# Altium schematic sheet Connectors.SchDoc
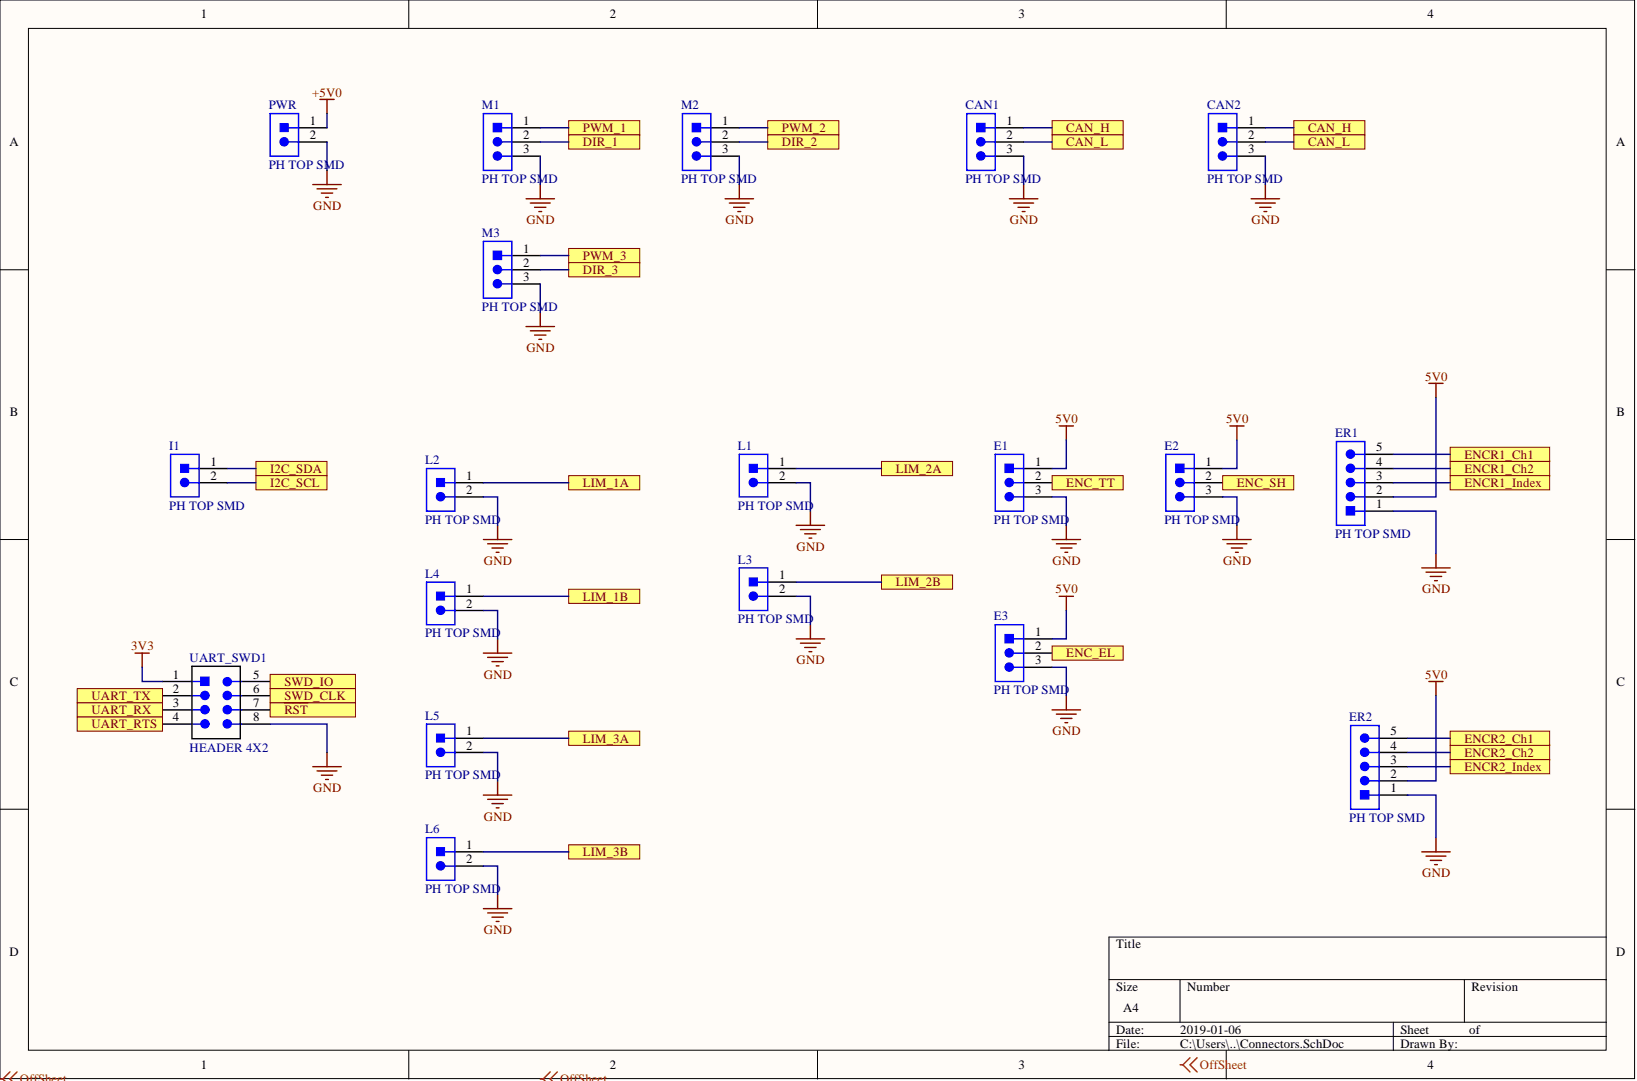
[diagram p0: Connectors.SchDoc]

## Parts
CAN1  PH TOP SMD  [JST-B3B-SM4-TB]
CAN2  PH TOP SMD  [JST-B3B-SM4-TB]
E1  PH TOP SMD  [JST-B3B-SM4-TB]
E2  PH TOP SMD  [JST-B3B-SM4-TB]
E3  PH TOP SMD  [JST-B3B-SM4-TB]
ER1  PH TOP SMD  [JST-B5B-SM4-TB]
ER2  PH TOP SMD  [JST-B5B-SM4-TB]
I1  PH TOP SMD  [JST-B2B-SM4-TB]
L1  PH TOP SMD  [JST-B2B-SM4-TB]
L2  PH TOP SMD  [JST-B2B-SM4-TB]
L3  PH TOP SMD  [JST-B2B-SM4-TB]
L4  PH TOP SMD  [JST-B2B-SM4-TB]
L5  PH TOP SMD  [JST-B2B-SM4-TB]
L6  PH TOP SMD  [JST-B2B-SM4-TB]
M1  PH TOP SMD  [JST-B3B-SM4-TB]
M2  PH TOP SMD  [JST-B3B-SM4-TB]
M3  PH TOP SMD  [JST-B3B-SM4-TB]
PWR  PH TOP SMD  [JST-B2B-SM4-TB]
UART_SWD1  HEADER 4X2  [HEADER_2X4]

## Ports
CAN_H, CAN_L, DIR_1, DIR_2, DIR_3, ENC_EL, ENC_SH, ENC_TT, ENCR1_Ch1, ENCR1_Ch2, ENCR1_Index, ENCR2_Ch1, ENCR2_Ch2, ENCR2_Index, I2C_SCL, I2C_SDA, LIM_1A, LIM_1B, LIM_2A, LIM_2B, LIM_3A, LIM_3B, PWM_1, PWM_2, PWM_3, SWD_CLK, SWD_IO, UART_RTS, UART_RX, UART_TX, ~{RST}

## Nets
3V3: UART_SWD1.1
5V0: E1.1 E2.1 E3.1 ER1.2 ER2.2
+5V0: PWR.1
GND: CAN1.3 CAN2.3 E1.3 E2.3 E3.3 ER1.1 ER2.1 L1.2 L2.2 L3.2 L4.2 L5.2 L6.2 M1.3 M2.3 M3.3 PWR.2 UART_SWD1.8
~{RST}: UART_SWD1.7 ; port ~{RST}
CAN_H: CAN1.1 CAN2.1 ; port CAN_H
CAN_L: CAN1.2 CAN2.2 ; port CAN_L
DIR_1: M1.2 ; port DIR_1
DIR_2: M2.2 ; port DIR_2
DIR_3: M3.2 ; port DIR_3
ENC_EL: E3.2 ; port ENC_EL
ENC_SH: E2.2 ; port ENC_SH
ENC_TT: E1.2 ; port ENC_TT
ENCR1_Ch1: ER1.5 ; port ENCR1_Ch1
ENCR1_Ch2: ER1.4 ; port ENCR1_Ch2
ENCR1_Index: ER1.3 ; port ENCR1_Index
ENCR2_Ch1: ER2.5 ; port ENCR2_Ch1
ENCR2_Ch2: ER2.4 ; port ENCR2_Ch2
ENCR2_Index: ER2.3 ; port ENCR2_Index
I2C_SCL: I1.2 ; port I2C_SCL
I2C_SDA: I1.1 ; port I2C_SDA
LIM_1A: L2.1 ; port LIM_1A
LIM_1B: L4.1 ; port LIM_1B
LIM_2A: L1.1 ; port LIM_2A
LIM_2B: L3.1 ; port LIM_2B
LIM_3A: L5.1 ; port LIM_3A
LIM_3B: L6.1 ; port LIM_3B
PWM_1: M1.1 ; port PWM_1
PWM_2: M2.1 ; port PWM_2
PWM_3: M3.1 ; port PWM_3
SWD_CLK: UART_SWD1.6 ; port SWD_CLK
SWD_IO: UART_SWD1.5 ; port SWD_IO
UART_RTS: UART_SWD1.4 ; port UART_RTS
UART_RX: UART_SWD1.3 ; port UART_RX
UART_TX: UART_SWD1.2 ; port UART_TX
OffSheet:

## Library data of the parts
CAN1 CAN2 E1-E3 M1-M3 = CON_3M_PH_TOP_SMT: CONN HEADER PH TOP 3POS 2MM SMD; Manufacturer: JST; Part Number: B3B-PH-SM4-TB; Component Kind: Standard; ComponentLink1Description: Datasheet; ComponentLink1URL: http://www.jst-mfg.com/product/pdf/eng/ePH.pdf; Library Name: UWRT_Schematic_Library_2019.SchLib
ER1 ER2 = CON_5M_PH_TOP_SMT: CONN HEADER PH TOP 5POS 2MM SMD; Manufacturer: JST; Part Number: B5B-PH-SM4-TB; Component Kind: Standard; ComponentLink1Description: Datasheet; ComponentLink1URL: http://www.jst-mfg.com/product/pdf/eng/ePH.pdf; Library Name: UWRT_Schematic_Library_2019.SchLib
I1 L1-L6 PWR = CON_2M_PH_TOP_SMT: CONN HEADER PH TOP 2POS 2MM SMD; Manufacturer: JST; Part Number: B2B-PH-SM4-TB; Component Kind: Standard; ComponentLink1Description: Datasheet; ComponentLink1URL: http://www.jst-mfg.com/product/pdf/eng/ePH.pdf; Library Name: UWRT_Schematic_Library_2019.SchLib
UART_SWD1 = HEADER 4X2: Header, 4-Pin Dual row; Component Kind: Standard; LatestRevisionDate: 17-Jul-2002; LatestRevisionNote: Re-released for DXP Platform.; Signal Integrity: Connector; Library Name: UWRT_Schematic_Library_2019.SchLib; Publisher: Altium Limited
